# Altium schematic sheet AirQualityMonitor.SchDoc (continued)

## Library data of the parts
C1-C3 C7 C21 = 08056C106KAT2A: CAP CER 10UF 6.3V X7R 0805; Manufacturer: Kyocera AVX; Tolerance: 10%; Capacitance: 10uF; Case/Package: 0805; Case Code (Imperial): 0805; Case Code (Metric): 2012; Component Kind: Standard; ComponentLink1Description: http://www.farnell.com/datasheets/2095836.pdf; ComponentLink1URL: http://www.farnell.com/datasheets/2095836.pdf; ComponentLink2Description: http://avx.com/docs/Catalogs/cx7r.pdf; ComponentLink2URL: http://avx.com/docs/Catalogs/cx7r.pdf; ComponentLink3Description: http://www.mouser.com/ds/2/40/X7RDielectric-777024.pdf; ComponentLink3URL: http://www.mouser.com/ds/2/40/X7RDielectric-777024.pdf; ComponentLink4Description: https://www.verical.com/server-webapp/html/getDatasheet?partID=149687; ComponentLink4URL: https://www.verical.com/server-webapp/html/getDatasheet?partID=149687; ComponentLink5Description: http://www.avx.com/docs/catalogs/cx7r.pdf; ComponentLink5URL: http://www.avx.com/docs/catalogs/cx7r.pdf; ComponentLink6Description: http://catalogs.avx.com/SurfaceMount.pdf; ComponentLink6URL: http://catalogs.avx.com/SurfaceMount.pdf; ComponentLink7Description: http://datasheets.avx.com/X7RDielectric.pdf; ComponentLink7URL: http://datasheets.avx.com/X7RDielectric.pdf; Contact Plating: Matte,Tin; Depth: 1.25mm; Dielectric: X7R; Height: 1.52mm; Lead Free: Lead Free; Length: 2.01mm; Manufacturer Part Number: 08056C106KAT2A; Max Operating Temperature: 125°C; Min Operating Temperature: -55°C; Mount: PCB,Surface Mount; Number of Pins: 2; Package Quantity: 1; Packaging: Tape and Reel; Pricing 1: 1=0.83, 10=0.614, 100=0.4209, 500=0.33322, 1000=0.2806 (USD); Radiation Hardening: No; REACH SVHC: No SVHC; Stock 1: 0; Supplier 1: Digi-Key; Supplier Part Number 1: 478-5322-6-ND; Termination: SMD/SMT; Thickness: 0.055inch; Voltage: 6.3V; Voltage Rating: 6.3V; Voltage Rating (DC): 6.3V; Width: 0.049inch; Library Name: Breathalyzer.SchLib
C4 C10 C16 C18 = GRM188R71E104KA01D: CAP CER 0.1UF 25V X7R 0603; Manufacturer: Murata; Capacitance: 100nF; Material: Ceramic; Tolerance: 10%; Case/Package: 0603; Case Code (Imperial): 0603; Case Code (Metric): 1608; Component Kind: Standard; ComponentLink1Description: https://www.murata.com/~/media/webrenewal/support/library/catalog/products/capacitor/mlcc/c02e.ashx?la=en-us; ComponentLink1URL: https://www.murata.com/~/media/webrenewal/support/library/catalog/products/capacitor/mlcc/c02e.ashx?la=en-us; ComponentLink2Description: http://psearch.en.murata.com/capacitor/product/GRM188R71E103KA01%23.pdf; ComponentLink2URL: http://psearch.en.murata.com/capacitor/product/GRM188R71E103KA01%23.pdf; ComponentLink3Description: http://www.farnell.com/datasheets/1747454.pdf; ComponentLink3URL: http://www.farnell.com/datasheets/1747454.pdf; ComponentLink4Description: http://www.jameco.com/Jameco/Products/ProdDS/1857495.pdf; ComponentLink4URL: http://www.jameco.com/Jameco/Products/ProdDS/1857495.pdf; ComponentLink5Description: https://www.verical.com/server-webapp/html/getDatasheet?partID=44549; ComponentLink5URL: https://www.verical.com/server-webapp/html/getDatasheet?partID=44549; ComponentLink6Description: https://produktinfo.conrad.com/datenblaetter/450000-474999/451555-da-01-en-VS_KONDENSATOR_0603.pdf; ComponentLink6URL: https://produktinfo.conrad.com/datenblaetter/450000-474999/451555-da-01-en-VS_KONDENSATOR_0603.pdf; Depth: 0.8mm; Dielectric: X7R; Height: 0.8mm; Lead Free: Lead Free; Length: 1.6mm; Manufacturer Part Number: GRM188R71E104KA01D; Max Operating Temperature: 125°C; Min Operating Temperature: -55°C; Mount: Surface Mount; Number of Pins: 2; Package Quantity: 1; Packaging: Tape and Reel; Pricing 1: 1=0.13 (USD); Radiation Hardening: No; REACH SVHC: Unknown; Stock 1: 4000; Supplier 1: Digi-Key; Supplier Part Number 1: 490-1524-6-ND; Termination: SMD/SMT; Thickness: 0.035inch; Voltage Rating: 25V; Voltage Rating (DC): 25V; Weight: 7.1E-05oz; Width: 0.031inch; Library Name: Breathalyzer.SchLib
  C4 C10: Voltage: 25V
C5 C11 = GRM188R61A105KA61J: Multilayer Ceramic Capacitors MLCC - SMD/SMT 0603 1uF 10volts X5R 10%; Manufacturer: Murata; Voltage Rating: 10V; Case/Package: 0603; Case Code (Imperial): 0603; Case Code (Metric): 1608; Component Kind: Standard; ComponentLink1Description: https://www.murata.com/~/media/webrenewal/support/library/catalog/products/capacitor/mlcc/c02e.ashx?la=en-us; ComponentLink1URL: https://www.murata.com/~/media/webrenewal/support/library/catalog/products/capacitor/mlcc/c02e.ashx?la=en-us; Depth: 0.8mm; Dielectric: X5R; Height: 0.8mm; Length: 1.6mm; Manufacturer Part Number: GRM188R61A105KA61J; Max Operating Temperature: 85°C; Min Operating Temperature: -55°C; Mount: Surface Mount; Package Quantity: 1; Packaging: Tape and Reel; Radiation Hardening: No; REACH SVHC: No SVHC; Stock 1: 100000; Supplier 1: Digi-Key; Supplier Part Number 1: 490-6408-1-ND; Termination: SMD/SMT; Thickness: 0.035inch; Tolerance: 10%; Voltage Rating (DC): 10V; Width: 0.031inch; Library Name: Breathalyzer.SchLib
C6 = CC0805KKX7R8BB474: CAP CER 0.47UF 25V X7R 0805; Manufacturer: Yageo; Capacitance: 470nF; Dissipation Factor: 5%; Insulation Resistance: 10GR; Tolerance: 10%; Case/Package: 0805; Case Code (Imperial): 0805; Case Code (Metric): 2012; Component Kind: Standard; ComponentLink1Description: http://documents.rs-components.com/techlib://itc_doclist_GB.htm?stockno=6963572; ComponentLink1URL: http://documents.rs-components.com/techlib://itc_doclist_GB.htm?stockno=6963572; ComponentLink2Description: http://www.farnell.com/datasheets/1489800.pdf; ComponentLink2URL: http://www.farnell.com/datasheets/1489800.pdf; ComponentLink3Description: http://www.yageo.com/documents/recent/UPY-GPHC_X7R_6.3V-to-50V_18.pdf; ComponentLink3URL: http://www.yageo.com/documents/recent/UPY-GPHC_X7R_6.3V-to-50V_18.pdf; ComponentLink4Description: https://www.verical.com/server-webapp/html/getDatasheet?partID=315216; ComponentLink4URL: https://www.verical.com/server-webapp/html/getDatasheet?partID=315216; ComponentLink5Description: http://www.mouser.com/ds/2/447/Yageo_UPY-GP_NP0_16V-to-50V_9-358835.pdf; ComponentLink5URL: http://www.mouser.com/ds/2/447/Yageo_UPY-GP_NP0_16V-to-50V_9-358835.pdf; Composition: Ceramic; Depth: 1.25mm; Dielectric: X7R; Halogen Free: Halogen Free; Height: 1.25mm; Lead Free: Lead Free; Length: 2mm; Manufacturer Part Number: CC0805KKX7R8BB474; Max Operating Temperature: 125°C; Min Operating Temperature: -55°C; Mount: Surface Mount; Number of Pins: 2; Package Quantity: 3000; Packaging: Tape and Reel; Pricing 1: 1=0.29, 10=0.197, 100=0.0997, 500=0.06824, 1000=0.06037 (USD); Radiation Hardening: No; REACH SVHC: No SVHC; Stock 1: 0; Supplier 1: Digi-Key; Supplier Part Number 1: 311-1364-6-ND; Termination: SMD/SMT; Thickness: 0.049inch; Voltage: 25V; Voltage Rating: 25V; Voltage Rating (DC): 25V; Width: 0.049inch; Library Name: Breathalyzer.SchLib
C8 C9 C15 C17 = TMK316BJ106ML-T: CAP CER 10UF 25V X5R 1206; Manufacturer: Taiyo Yuden; Tolerance: 10%; Case/Package: 1206; Case Code (Imperial): 1206; Case Code (Metric): 3216; Component Kind: Standard; ComponentLink1Description: https://www.yuden.co.jp/productdata/catalog/mlcc01_e.pdf; ComponentLink1URL: https://www.yuden.co.jp/productdata/catalog/mlcc01_e.pdf; ComponentLink2Description: http://www.farnell.com/datasheets/1481447.pdf; ComponentLink2URL: http://www.farnell.com/datasheets/1481447.pdf; ComponentLink3Description: http://www.farnell.com/datasheets/746258.pdf; ComponentLink3URL: http://www.farnell.com/datasheets/746258.pdf; Composition: Ceramic; Contact Plating: Nickel; Depth: 1.6mm; Dielectric: X5R; Halogen Free: Halogen Free; Height: 1.6mm; Lead Free: Lead Free; Length: 3.2mm; Manufacturer Part Number: TMK316BJ106ML-T; Max Operating Temperature: 85°C; Min Operating Temperature: -55°C; Mount: Surface Mount; Number of Pins: 2; Package Quantity: 1; Packaging: Tape and Reel; Pricing 1: 1=0.34, 10=0.236, 100=0.1339, 500=0.09454, 1000=0.08271 (USD); Radiation Hardening: No; REACH SVHC: Unknown; Stock 1: 0; Supplier 1: Digi-Key; Supplier Part Number 1: 587-2259-6-ND; Termination: SMD/SMT; Thickness: 0.071inch; Voltage Rating: 25V; Voltage Rating (DC): 25V; Width: 1.6mm; Library Name: Breathalyzer.SchLib
  C8 C15 C17: Voltage: 25V
C12 = GRM31CR60J107ME39K: Multilayer Ceramic Capacitors MLCC - SMD/SMT 1206 100uF 6.3volt X5R +/-20%; Manufacturer: Murata; Tolerance: 20%; Case/Package: 1206; Case Code (Imperial): 1206; Case Code (Metric): 3216; Component Kind: Standard; ComponentLink1Description: http://www.mouser.com/ds/2/281/GRM31A7U2H471JW31-01-1064911.pdf; ComponentLink1URL: http://www.mouser.com/ds/2/281/GRM31A7U2H471JW31-01-1064911.pdf; ComponentLink2Description: https://www.murata.com/~/media/webrenewal/support/library/catalog/products/capacitor/mlcc/c02e.ashx?la=en-us; ComponentLink2URL: https://www.murata.com/~/media/webrenewal/support/library/catalog/products/capacitor/mlcc/c02e.ashx?la=en-us; Depth: 1.6mm; Dielectric: X5R; Height: 1.6mm; Lead Free: Lead Free; Length: 3.2mm; Manufacturer Part Number: GRM31CR60J107ME39K; Max Operating Temperature: 85°C; Min Operating Temperature: -55°C; Mount: Surface Mount; Package Quantity: 1; Packaging: Tape and Reel; Radiation Hardening: No; REACH SVHC: No SVHC; Stock 1: 0; Supplier 1: Digi-Key; Supplier Part Number 1: GRM31CR60J107ME39K-ND; Termination: SMD/SMT; Thickness: 0.071inch; Voltage: 6.3V; Voltage Rating: 6.3V; Voltage Rating (DC): 6.3V; Width: 0.063inch; Library Name: Breathalyzer.SchLib
C13 C14 C19 C20 = UMK107SD102KA-T: CAP CER 1000PF 50V 0603; Manufacturer: Taiyo Yuden; Dielectric Material: Ceramic; Tolerance: 10%; Case/Package: 0603; Case Code (Imperial): 0603; Case Code (Metric): 1608; Component Kind: Standard; ComponentLink1Description: http://www.yuden.co.jp/productdata/catalog/en/mlcc07_e.pdf; ComponentLink1URL: http://www.yuden.co.jp/productdata/catalog/en/mlcc07_e.pdf; ComponentLink2Description: http://documents.rs-components.com/techlib://itc_doclist_GB.htm?stockno=7587377; ComponentLink2URL: http://documents.rs-components.com/techlib://itc_doclist_GB.htm?stockno=7587377; ComponentLink3Description: http://ds.yuden.co.jp/TYCOMPAS/ut/detail.do?productNo=UMK107SD102KA-T&fileName=UMK107SD102KA-T_SS&mode=specSheetDownload; ComponentLink3URL: http://ds.yuden.co.jp/TYCOMPAS/ut/detail.do?productNo=UMK107SD102KA-T&fileName=UMK107SD102KA-T_SS&mode=specSheetDownload; Depth: 0.8mm; Halogen Free: Halogen Free; Height: 0.8mm; Lead Free: Lead Free; Length: 1.6mm; Manufacturer Part Number: UMK107SD102KA-T; Max Operating Temperature: 125°C; Min Operating Temperature: -55°C; Mount: Surface Mount; Number of Pins: 2; Package Quantity: 1; Packaging: Tape and Reel; Pricing 1: 1=0.36, 10=0.252, 100=0.1509, 500=0.1132, 1000=0.09559 (USD); Radiation Hardening: No; Stock 1: 4000; Supplier 1: Digi-Key; Supplier Part Number 1: 587-1070-6-ND; Termination: SMD/SMT; Thickness: 0.035inch; Voltage Rating: 50V; Voltage Rating (DC): 50V; Width: 0.8mm; Library Name: Breathalyzer.SchLib
  C14: Capacitance: 1nF; Voltage: 50V
D1 = LTST-C193TBKT-5A: Standard LEDs - SMD Blue 470nm 28mcd 5mA; Manufacturer: Vishay Lite-On; Dominant Wavelength: 475nm; Forward Current: 20mA; Forward Voltage: 2.8V; Lens Colour: Clear,Colorless; Lens Style: Clear,Colorless,Diffused,Rectangular; Lens Transparency: Clear; Luminous Intensity: 15mcd; Number of Elements: 1; Power Dissipation: 76mW; Reverse Voltage: 5V; Viewing Angle: 130°; Case/Package: 0603; Case Code (Imperial): 0603; Case Code (Metric): 1608; Colour: Blue; Component Kind: Standard; ComponentLink1Description: http://www.mouser.com/ds/2/239/Lite-On-LTST-C193TBKT-5A-187386.pdf; ComponentLink1URL: http://www.mouser.com/ds/2/239/Lite-On-LTST-C193TBKT-5A-187386.pdf; ComponentLink2Description: https://media.digikey.com/pdf/Data%20Sheets/Lite-On%20PDFs/LTST-C193TBKT-5A.pdf; ComponentLink2URL: https://media.digikey.com/pdf/Data%20Sheets/Lite-On%20PDFs/LTST-C193TBKT-5A.pdf; ComponentLink3Description: https://www.verical.com/server-webapp/html/getDatasheet?partID=293056; ComponentLink3URL: https://www.verical.com/server-webapp/html/getDatasheet?partID=293056; ComponentLink4Description: http://uk.rs-online.com/webdocs/0dc0/0900766b80dc0554.pdf; ComponentLink4URL: http://uk.rs-online.com/webdocs/0dc0/0900766b80dc0554.pdf; ComponentLink5Description: http://www1.futureelectronics.com/doc/LITE-ON/LTST-C193TBKT-5A.pdf; ComponentLink5URL: http://www1.futureelectronics.com/doc/LITE-ON/LTST-C193TBKT-5A.pdf; Depth: 0.8mm; Height: 0.35mm; Illumination Colour: Blue; Lead Free: Lead Free; Length: 1.6mm; Manufacturer Part Number: LTST-C193TBKT-5A; Max Operating Temperature: 80°C; Min Operating Temperature: -20°C; Mount: Surface Mount; Number of LEDs: 1; Number of Pins: 2; Package Quantity: 1; Packaging: Tape and Reel; Pricing 1: 1=0.43, 10=0.23, 50=0.23, 100=0.108, 1000=0.078, 10000=0.055 (USD); Radiation Hardening: No; Stock 1: 100531; Supplier 1: Mouser; Supplier Part Number 1: 859-LTSTC193TBKT5A; Test Current: 5mA; Width: 0.8mm; Library Name: Breathalyzer.SchLib
D2 = APT2012SRCPRV: LED 2X1.2MM 640NM RD WTR CLR SMD; Manufacturer: Kingbright; Forward Current: 20mA; Forward Voltage: 1.85V; Lens Color: Clear; Lens Style: Clear,Flat; Luminous Intensity: 100mcd; Number of LEDs: 1; Power Rating: 75mW; Viewing Angle: 120°; Wavelength: 655nm; Case/Package: 0805; Case Code (Imperial): 0805; Case Code (Metric): 2012; Color: Red; Component Kind: Standard; ComponentLink1Description: http://www.mouser.com/ds/2/216/APT2012SRCPRV-56885.pdf; ComponentLink1URL: http://www.mouser.com/ds/2/216/APT2012SRCPRV-56885.pdf; ComponentLink2Description: http://www.kingbrightusa.com/images/catalog/SPEC/APT2012SRCPRV.pdf; ComponentLink2URL: http://www.kingbrightusa.com/images/catalog/SPEC/APT2012SRCPRV.pdf; ComponentLink3Description: http://www.rapidonline.com/pdf/72-9588.pdf; ComponentLink3URL: http://www.rapidonline.com/pdf/72-9588.pdf; Dominant Wavelength: 640nm; Height: 0.75mm; Illumination Color: Red; Lead Free: Lead Free; Length: 2mm; Manufacturer Part Number: APT2012SRCPRV; Max Operating Temperature: 85°C; Min Operating Temperature: -40°C; Mount: Surface Mount; Number of Pins: 2; Package Quantity: 2000; Packaging: Tape and Reel; Pricing 1: 1=0.43, 10=0.266, 50=0.266, 100=0.128, 1000=0.082, 10000=0.053 (USD); Stock 1: 64032; Supplier 1: Mouser; Supplier Part Number 1: 604-APT2012SRCPRV; Test Current: 20mA; Width: 1.25mm; Library Name: Breathalyzer.SchLib
J1 = 10118194-0001LF: Conn Micro USB Type B RCP 5 POS 0.65mm Solder RA SMD 5 Terminal 1 Port T/R; MF: Amphenol FCI; Availability: Unavailable; Component Kind: Standard; MP: 10118194-0001LF; Package: None; Price: None; SnapEDA_Link: https://www.snapeda.com/parts/10118194-0001LF/Amphenol%20ICC/view-part/?ref=snap; Library Name: Breathalyzer.SchLib
J2 = I2C Testing: 1x4 Header for I2C Testing; Component Kind: Standard; Library Name: Breathalyzer.SchLib
J3 = S2B-PH-SM4-TB: Socket; wire-board; male; PIN: 2; 2mm; SMT; PH; 100V; 2A; -25Ã·85Â°C; MF: JST; Availability: Unavailable; Component Kind: Standard; MP: S2B-PH-SM4-TB; Package: None; Price: None; SnapEDA_Link: https://www.snapeda.com/parts/S2B-PH-SM4-TB/JST/view-part/?ref=snap; Library Name: Breathalyzer.SchLib
L1 L2 = VLF4012AT-2R2M1R5: FIXED IND 2.2UH 1.5A 87 MOHM SMD; Manufacturer: TDK; Core Material: Ferrite; DC Resistance (DCR): 87mR; Inductance Tolerance: 20%; Shielding: Shielded; Test Frequency: 100kHz; Case/Package: Nonstandard; Component Kind: Standard; ComponentLink1Description: http://www.farnell.com/datasheets/333336.pdf; ComponentLink1URL: http://www.farnell.com/datasheets/333336.pdf; ComponentLink2Description: http://www.onlinecomponents.com/productFiles/mf-TDK/e531_vlf4012a.pdf; ComponentLink2URL: http://www.onlinecomponents.com/productFiles/mf-TDK/e531_vlf4012a.pdf; Composition: Wirewound; DC Current: 1.5A; Depth: 3.8mm; Height: 1.2mm; Height - Seated (Max): 0.047inch; Inductance: 2.2uh; Lead Free: Lead Free; Length: 0.146inch; Manufacturer Part Number: VLF4012AT-2R2M1R5; Max DC Current: 1.5A; Max Operating Temperature: 105°C; Military Standard: Not; Min Operating Temperature: -40°C; Mount: Surface Mount; Number of Pins: 2; Package Quantity: 1000; Packaging: Tape and Reel; Pricing 1: 1=0.83, 10=0.725, 100=0.5175, 500=0.4347 (USD); Radiation Hardening: No; REACH SVHC: No SVHC; RMS Current (Irms): 1.5A; Saturation Current: 1.5A; Stock 1: 94427; Supplier 1: Digi-Key; Supplier Part Number 1: 445-3233-1-ND; Termination: SMD/SMT; Tolerance: 20%; Width: 0.138inch; Library Name: Breathalyzer.SchLib
Q1 Q2 = MMSS8050-L-TP: TRANS NPN 25V 1.5A SOT-23; Manufacturer: MCC; Collector Base Voltage (VCBO): 40V; Collector Emitter Saturation Voltage: 500mV; Element Configuration: Single; Emitter Base Voltage (VEBO): 5V; Gain Bandwidth Product: 100MHz; hFE Min: 40; Max Power Dissipation: 625mW; Case/Package: TO-236-3; Collector Emitter Breakdown Voltage: 25V; Collector Emitter Voltage (VCEO): 500mV; Component Kind: Standard; ComponentLink1Description: http://www.mccsemi.com/up_pdf/MMSS8050(SOT-23).pdf; ComponentLink1URL: http://www.mccsemi.com/up_pdf/MMSS8050(SOT-23).pdf; ComponentLink2Description: http://www.mouser.com/ds/2/258/MMSS8050(SOT-23)-276387.pdf; ComponentLink2URL: http://www.mouser.com/ds/2/258/MMSS8050(SOT-23)-276387.pdf; Lead Free: Lead Free; Manufacturer Part Number: MMSS8050-L-TP; Max Breakdown Voltage: 25V; Max Collector Current: 1.5A; Max Operating Temperature: 150°C; Min Operating Temperature: -55°C; Mount: Surface Mount; Package Quantity: 3000; Packaging: Tape and Reel; Polarity: NPN; Pricing 1: 1=0.21, 10=0.19, 25=0.1728, 100=0.1246, 250=0.07336, 500=0.0609, 1000=0.04152 (USD); Stock 1: 28424; Supplier 1: Digi-Key; Supplier Part Number 1: MMSS8050-L-TPMSDKR-ND; Transition Frequency: 100MHz; Library Name: Breathalyzer.SchLib
Q3 = DMP2045U-7: P-Channel MOSFET 045R at VGS=-4.5V ID = -4.3A; Manufacturer: Diodes; Component Kind: Standard; ComponentLink1Description: Datasheet; ComponentLink1URL: https://www.diodes.com/assets/Datasheets/DMP2045U.pdf; Manufacturer Part Number: DMP2045U-7; Pricing 1: 1=0.45, 10=0.289, 50=0.289, 100=0.124, 1000=0.095, 10000=0.064 (USD); Stock 1: 0; Supplier 1: Mouser; Supplier Part Number 1: 621-DMP2045U-7; Library Name: Breathalyzer.SchLib
R1 R3 R5 R6 R10 R11 R17 R18 = RC0805FR-0710KL: RES SMD 10K OHM 1% 1/8W 0805; Manufacturer: Yageo; Max Power Dissipation: 125mW; Resistance: 10kR; Temperature Coefficient: 100ppm/°C; Voltage Rating: 150V; Case/Package: 0805; Case Code (Imperial): 0805; Case Code (Metric): 2012; Component Kind: Standard; ComponentLink1Description: http://www.yageo.com/documents/recent/PYu-RC_Group_51_RoHS_L_9.pdf; ComponentLink1URL: http://www.yageo.com/documents/recent/PYu-RC_Group_51_RoHS_L_9.pdf; ComponentLink2Description: http://www.farnell.com/datasheets/444164.pdf; ComponentLink2URL: http://www.farnell.com/datasheets/444164.pdf; ComponentLink3Description: http://www.yageo.ru/pdf/RC0805.pdf; ComponentLink3URL: http://www.yageo.ru/pdf/RC0805.pdf; Composition: Thick Film; Depth: 1.25mm; Features: Moisture Resistant; Height: 0.024inch; Lead Free: Lead Free; Length: 2mm; Manufacturer Part Number: RC0805FR-0710KL; Max Operating Temperature: 155°C; Military Standard: Not; Min Operating Temperature: -55°C; Number of Terminations: 2; Package Quantity: 1; Packaging: Tape and Reel; Power Rating: 125mW; Pricing 1: 1=0.1, 10=0.046, 100=0.0186, 1000=0.00835, 2500=0.00725 (USD); REACH SVHC: Unknown; Stock 1: 50767607; Supplier 1: Digi-Key; Supplier Part Number 1: 311-10.0KCRDKR-ND; Termination: SMD/SMT; Width: 0.049inch; Library Name: Breathalyzer.SchLib
  R1 R17 R18: Tolerance: 1%
R2 R9 = RC0805JR-07100RL: RES, THICK FILM, 100R, 5%, 0.125W, 0805; Manufacturer: Yageo; Power Rating: 125mW; Temperature Coefficient: 100ppm/°C; Voltage Rating: 150V; Case/Package: 0805; Case Code (Imperial): 0805; Case Code (Metric): 2012; Component Kind: Standard; ComponentLink1Description: http://www.farnell.com/datasheets/444164.pdf; ComponentLink1URL: http://www.farnell.com/datasheets/444164.pdf; ComponentLink2Description: http://www.farnell.com/datasheets/2167052.pdf; ComponentLink2URL: http://www.farnell.com/datasheets/2167052.pdf; ComponentLink3Description: http://www.farnell.com/datasheets/442941.pdf; ComponentLink3URL: http://www.farnell.com/datasheets/442941.pdf; ComponentLink4Description: http://www.yageo.ru/pdf/RC0805.pdf; ComponentLink4URL: http://www.yageo.ru/pdf/RC0805.pdf; Composition: Thick Film; Contact Plating: Lead,Matte,Tin; Lead Free: Lead Free; Manufacturer Part Number: RC0805JR-07100RL; Max Operating Temperature: 155°C; Min Operating Temperature: -55°C; Packaging: Tape and Reel; Pricing 1: 1=0.11, 10=0.026, 50=0.026, 100=0.009, 1000=0.007, 10000=0.004 (USD); Radiation Hardening: No; REACH SVHC: Unknown; Resistance: 100R; Stock 1: 497207; Supplier 1: Mouser; Supplier Part Number 1: 603-RC0805JR-07100RL; Termination: SMD/SMT; Tolerance: 5%; Library Name: Breathalyzer.SchLib
R4 = RC0603FR-07100KL: YAGEO         RC0603FR-07100KL             RES, THICK FILM, 100K, 1%, 0.1W, 0603; Power Rating: 100mW; Resistance: 100kR; Temperature Coefficient: 100ppm/°C; Voltage Rating: 50V; Working Voltage: 75V; Case/Package: 0603; Case Code (Imperial): 0603; Case Code (Metric): 1608; Component Kind: Standard; ComponentLink1Description: http://www.farnell.com/datasheets/2167052.pdf; ComponentLink1URL: http://www.farnell.com/datasheets/2167052.pdf; ComponentLink2Description: http://www.farnell.com/datasheets/1868787.pdf; ComponentLink2URL: http://www.farnell.com/datasheets/1868787.pdf; ComponentLink3Description: http://www.farnell.com/datasheets/1738695.pdf; ComponentLink3URL: http://www.farnell.com/datasheets/1738695.pdf; ComponentLink4Description: http://documents.rs-components.com/techlib://itc_doclist_GB.htm?stockno=5048940; ComponentLink4URL: http://documents.rs-components.com/techlib://itc_doclist_GB.htm?stockno=5048940; ComponentLink5Description: http://www.farnell.com/datasheets/443971.pdf; ComponentLink5URL: http://www.farnell.com/datasheets/443971.pdf; Composition: Thick Film; Depth: 0.8mm; Height: 0.45mm; Lead Free: Lead Free; Length: 1.6mm; Manufacturer: Yageo; Manufacturer Part Number: RC0603FR-07100KL; Max Operating Temperature: 155°C; Military Standard: Not; Min Operating Temperature: -55°C; Number of Pins: 2; Number of Terminations: 2; Packaging: Tape and Reel; Pricing 1: 1=0.1, 10=0.018, 50=0.018, 100=0.006, 1000=0.005, 10000=0.003 (USD); REACH SVHC: No SVHC; Stock 1: 667937; Supplier 1: Mouser; Supplier Part Number 1: 603-RC0603FR-07100KL; Termination: SMD/SMT; Tolerance: 1%; Voltage Rating (DC): 50V; Width: 0.8mm; Library Name: Breathalyzer.SchLib
R7 = CRCW080518K0FKEA: RES SMD 18K OHM 1% 1/8W 0805; Manufacturer: Vishay; Max Power Dissipation: 125mW; Resistance: 18kR; Temperature Coefficient: 100ppm/°C; Voltage Rating: 150V; Case/Package: 0805; Case Code (Imperial): 0805; Case Code (Metric): 2012; Component Kind: Standard; ComponentLink1Description: http://www.vishay.com/docs/20035/dcrcwe3.pdf; ComponentLink1URL: http://www.vishay.com/docs/20035/dcrcwe3.pdf; ComponentLink2Description: https://www.distrelec.biz/Web/Downloads/a_/en/flD-CRCW-e3_data_en.pdf?mime=application%2Fpdf; ComponentLink2URL: https://www.distrelec.biz/Web/Downloads/a_/en/flD-CRCW-e3_data_en.pdf?mime=application%2Fpdf; ComponentLink3Description: http://www.farnell.com/datasheets/2046716.pdf; ComponentLink3URL: http://www.farnell.com/datasheets/2046716.pdf; ComponentLink4Description: http://www.alliedelec.com/m/d/0c934d6939ccefa68dbe0b96d821c8cf.pdf; ComponentLink4URL: http://www.alliedelec.com/m/d/0c934d6939ccefa68dbe0b96d821c8cf.pdf; ComponentLink5Description: http://uk.rs-online.com/webdocs/0d98/0900766b80d98b26.pdf; ComponentLink5URL: http://uk.rs-online.com/webdocs/0d98/0900766b80d98b26.pdf; ComponentLink6Description: http://www.mouser.com/ds/2/427/dcrcwe3-109170.pdf; ComponentLink6URL: http://www.mouser.com/ds/2/427/dcrcwe3-109170.pdf; Composition: Thick Film; Contact Plating: Tin; Depth: 1.25mm; Features: Automotive AEC-Q200; Height: 0.45mm; Lead Free: Lead Free; Length: 2mm; Manufacturer Part Number: CRCW080518K0FKEA; Max Operating Temperature: 155°C; Military Standard: Not; Min Operating Temperature: -55°C; Number of Pins: 2; Number of Terminations: 2; Package Quantity: 10; Packaging: Tape and Reel; Power Rating: 125mW; Pricing 1: 1=0.1, 10=0.069, 100=0.028, 1000=0.01256, 2500=0.0109 (USD); Radiation Hardening: No; REACH SVHC: Unknown; Stock 1: 0; Supplier 1: Digi-Key; Supplier Part Number 1: 541-18.0KCDKR-ND; Termination: SMD/SMT; Tolerance: 1%; Voltage Rating (DC): 150V; Weight: 0.000194oz; Width: 0.049inch; Working Voltage: 150V; Library Name: Breathalyzer.SchLib
R8 R20 R22 R23 = CRCW08051K60FKEA: RES SMD 1.6K OHM 1% 1/8W 0805; Manufacturer: Vishay; Max Power Dissipation: 125mW; Resistance: 1.6kR; Temperature Coefficient: 100ppm/°C; Voltage Rating: 150V; Case/Package: 0805; Case Code (Imperial): 0805; Case Code (Metric): 2012; Component Kind: Standard; ComponentLink1Description: http://www.vishay.com/docs/20035/dcrcwe3.pdf; ComponentLink1URL: http://www.vishay.com/docs/20035/dcrcwe3.pdf; ComponentLink2Description: http://uk.rs-online.com/webdocs/0d98/0900766b80d98b26.pdf; ComponentLink2URL: http://uk.rs-online.com/webdocs/0d98/0900766b80d98b26.pdf; ComponentLink3Description: http://www.mouser.com/ds/2/427/dcrcwe3-109170.pdf; ComponentLink3URL: http://www.mouser.com/ds/2/427/dcrcwe3-109170.pdf; ComponentLink4Description: http://www.farnell.com/datasheets/2111203.pdf; ComponentLink4URL: http://www.farnell.com/datasheets/2111203.pdf; ComponentLink5Description: http://www.farnell.com/datasheets/2046716.pdf; ComponentLink5URL: http://www.farnell.com/datasheets/2046716.pdf; ComponentLink6Description: http://www.farnell.com/datasheets/1847332.pdf; ComponentLink6URL: http://www.farnell.com/datasheets/1847332.pdf; ComponentLink7Description: http://www.farnell.com/datasheets/1697337.pdf; ComponentLink7URL: http://www.farnell.com/datasheets/1697337.pdf; ComponentLink8Description: https://www.distrelec.biz/Web/Downloads/a_/en/flD-CRCW-e3_data_en.pdf?mime=application%2Fpdf; ComponentLink8URL: https://www.distrelec.biz/Web/Downloads/a_/en/flD-CRCW-e3_data_en.pdf?mime=application%2Fpdf; Composition: Thick Film; Contact Plating: Tin; Depth: 1.25mm; Features: Automotive AEC-Q200; Height: 0.45mm; Lead Free: Lead Free; Length: 2mm; Manufacturer Part Number: CRCW08051K60FKEA; Max Operating Temperature: 155°C; Military Standard: Not; Min Operating Temperature: -55°C; Number of Pins: 2; Number of Terminations: 2; Package Quantity: 5000; Packaging: Tape and Reel; Power Rating: 125mW; Pricing 1: 1=0.1, 10=0.069, 100=0.028, 1000=0.01256, 2500=0.0109 (USD); Radiation Hardening: No; REACH SVHC: Unknown; Stock 1: 12623; Supplier 1: Digi-Key; Supplier Part Number 1: 541-1.60KCDKR-ND; Termination: SMD/SMT; Tolerance: 1%; Voltage Rating (DC): 150V; Weight: 0.000194oz; Width: 0.049inch; Library Name: Breathalyzer.SchLib
R12 R19 R21 = RC0805FR-07470RL: RES SMD 470 OHM 1% 1/8W 0805; Manufacturer: Yageo; Max Power Dissipation: 125mW; Temperature Coefficient: 100ppm/°C; Voltage Rating: 150V; Case/Package: 0805; Case Code (Imperial): 0805; Case Code (Metric): 2012; Component Kind: Standard; ComponentLink1Description: http://www.yageo.com/documents/recent/PYu-RC_Group_51_RoHS_L_9.pdf; ComponentLink1URL: http://www.yageo.com/documents/recent/PYu-RC_Group_51_RoHS_L_9.pdf; ComponentLink2Description: http://www.farnell.com/datasheets/444164.pdf; ComponentLink2URL: http://www.farnell.com/datasheets/444164.pdf; ComponentLink3Description: http://documents.rs-components.com/techlib://itc_doclist_GB.htm?stockno=6182546; ComponentLink3URL: http://documents.rs-components.com/techlib://itc_doclist_GB.htm?stockno=6182546; ComponentLink4Description: http://www.yageo.ru/pdf/RC0805.pdf; ComponentLink4URL: http://www.yageo.ru/pdf/RC0805.pdf; Composition: Thick Film; Depth: 1.25mm; Features: Moisture Resistant; Height: 0.5mm; Lead Free: Lead Free; Lead Pitch: 0.4mm; Length: 2mm; Manufacturer Part Number: RC0805FR-07470RL; Max Operating Temperature: 155°C; Military Standard: Not; Min Operating Temperature: -55°C; Number of Pins: 2; Number of Terminations: 2; Package Quantity: 1; Packaging: Tape and Reel; Power Rating: 125mW; Pricing 1: 1=0.1, 10=0.046, 100=0.0186, 1000=0.00835, 2500=0.00725 (USD); REACH SVHC: Unknown; Stock 1: 2436062; Supplier 1: Digi-Key; Supplier Part Number 1: 311-470CRDKR-ND; Termination: SMD/SMT; Voltage Rating (DC): 150V; Width: 1.25mm; Library Name: Breathalyzer.SchLib
R13 R14 = RC0603FR-070RL: Thick Film Resistors - SMD 0.0ohm 1%; Manufacturer: Yageo; Current Rating: 1A; Max Power Dissipation: 100mW; Resistance: 0mR; Temperature Coefficient: 200ppm/°C; Voltage Rating: 50V; Working Voltage: 75V; Case/Package: 0603; Case Code (Imperial): 0603; Case Code (Metric): 1608; Component Kind: Standard; ComponentLink1Description: http://www.farnell.com/datasheets/1868787.pdf; ComponentLink1URL: http://www.farnell.com/datasheets/1868787.pdf; ComponentLink2Description: http://www.farnell.com/datasheets/443971.pdf; ComponentLink2URL: http://www.farnell.com/datasheets/443971.pdf; ComponentLink3Description: http://www.yageo.com/documents/recent/PYu-RC_Group_51_RoHS_L_9.pdf; ComponentLink3URL: http://www.yageo.com/documents/recent/PYu-RC_Group_51_RoHS_L_9.pdf; ComponentLink4Description: http://documents.rs-components.com/techlib://itc_doclist_GB.htm?stockno=5048827; ComponentLink4URL: http://documents.rs-components.com/techlib://itc_doclist_GB.htm?stockno=5048827; Composition: Thick Film; Contact Plating: Matte,Tin; Depth: 0.8mm; Features: Moisture Resistant; Height: 0.45mm; Lead Free: Lead Free; Length: 1.6mm; Manufacturer Part Number: RC0603FR-070RL; Max Operating Temperature: 155°C; Military Standard: Not; Min Operating Temperature: -55°C; Number of Pins: 2; Number of Terminations: 2; Package Quantity: 5000; Packaging: Tape and Reel; Power Rating: 100mW; Radiation Hardening: No; REACH SVHC: No SVHC; Stock 1: 0; Supplier 1: Mouser; Supplier Part Number 1: 311-0.0HRCT-ND; Termination: SMD/SMT; Voltage Rating (DC): 50V; Width: 0.031inch; Library Name: Breathalyzer.SchLib
R15 R16 = RC0805JR-074K7L: YAGEO         RC0805JR-074K7L             RES, THICK FILM, 4K7, 5%, 0.125W, 0805; Power Rating: 125mW; Resistance: 4.7kR; Temperature Coefficient: 100ppm/°C; Voltage Rating: 150V; Case/Package: Molded; Case Code (Imperial): 0805; Case Code (Metric): 2012; Component Kind: Standard; ComponentLink1Description: http://www.farnell.com/datasheets/442941.pdf; ComponentLink1URL: http://www.farnell.com/datasheets/442941.pdf; ComponentLink2Description: http://www.yageo.ru/pdf/RC0805.pdf; ComponentLink2URL: http://www.yageo.ru/pdf/RC0805.pdf; ComponentLink3Description: http://www.mouser.com/ds/2/447/Yageo_08112016_RC0805-968729.pdf; ComponentLink3URL: http://www.mouser.com/ds/2/447/Yageo_08112016_RC0805-968729.pdf; Composition: Thick Film; Contact Plating: Lead,Matte,Tin; Depth: 1.25mm; Lead Free: Lead Free; Length: 2mm; Manufacturer: Yageo; Manufacturer Part Number: RC0805JR-074K7L; Max Operating Temperature: 155°C; Military Standard: Not; Min Operating Temperature: -55°C; Packaging: Tape and Reel; Pricing 1: 1=0.1, 10=0.036, 100=0.0146, 1000=0.00654, 2500=0.00568 (USD); Radiation Hardening: No; REACH SVHC: Unknown; Stock 1: 4671769; Supplier 1: Digi-Key; Supplier Part Number 1: 311-4.7KARDKR-ND; Termination: SMD/SMT; Tolerance: 5%; Library Name: Breathalyzer.SchLib
R24 = ERJ-8BWFR010V: Current Sense Resistor, 0.01 ohm, 1206 [3216 Metric], 1 W,  1%, ERJ8B Series; Manufacturer: Panasonic; Resistance: 10mR; Temperature Coefficient: 200ppm/°C; Case/Package: 1206; Case Code (Imperial): 1206; Case Code (Metric): 3216; Component Kind: Standard; ComponentLink1Description: http://uk.rs-online.com/webdocs/13b8/0900766b813b869e.pdf; ComponentLink1URL: http://uk.rs-online.com/webdocs/13b8/0900766b813b869e.pdf; ComponentLink2Description: http://industrial.panasonic.com/www-data/pdf/AOA0000/AOA0000CE3.pdf; ComponentLink2URL: http://industrial.panasonic.com/www-data/pdf/AOA0000/AOA0000CE3.pdf; ComponentLink3Description: https://www.distrelec.biz/Web/Downloads/_t/ds/ERJ_eng_tds.pdf?mime=application%2Fpdf; ComponentLink3URL: https://www.distrelec.biz/Web/Downloads/_t/ds/ERJ_eng_tds.pdf?mime=application%2Fpdf; ComponentLink4Description: https://industrial.panasonic.com/cdbs/www-data/pdf/RDN0000/AOA0000C313.pdf; ComponentLink4URL: https://industrial.panasonic.com/cdbs/www-data/pdf/RDN0000/AOA0000C313.pdf; ComponentLink5Description: http://www.farnell.com/datasheets/39793.pdf; ComponentLink5URL: http://www.farnell.com/datasheets/39793.pdf; Composition: Low-Ohm-Resistor,Thick Film; Contact Plating: Tin; Depth: 1.6mm; Features: Automotive AEC-Q200; Height: 0.65mm; Lead Free: Lead Free; Length: 3.2mm; Manufacturer Part Number: ERJ-8BWFR010V; Max Operating Temperature: 155°C; Max Power Dissipation: 1W; Military Standard: Not; Min Operating Temperature: -55°C; Mount: Surface Mount; Number of Terminations: 2; Package Quantity: 1; Packaging: Tape and Reel; Power Rating: 1W; Pricing 1: 1=0.74, 10=0.618, 100=0.4148, 1000=0.2427, 2500=0.23475 (USD); Radiation Hardening: No; REACH SVHC: No SVHC; Stock 1: 131309; Supplier 1: Digi-Key; Supplier Part Number 1: P.010AUDKR-ND; Termination: SMD/SMT; Tolerance: 1%; Width: 1.6mm; Library Name: Breathalyzer.SchLib
R25 = CRCW080582R0FKEA: RES SMD 82 OHM 1% 1/8W 0805; Manufacturer: Vishay; Max Power Dissipation: 125mW; Temperature Coefficient: 100ppm/°C; Voltage Rating: 150V; Case/Package: 0805; Case Code (Imperial): 0805; Case Code (Metric): 2012; Component Kind: Standard; ComponentLink1Description: http://www.vishay.com/docs/20035/dcrcwe3.pdf; ComponentLink1URL: http://www.vishay.com/docs/20035/dcrcwe3.pdf; ComponentLink2Description: https://www.alliedelec.com/m/d/0c934d6939ccefa68dbe0b96d821c8cf.pdf; ComponentLink2URL: https://www.alliedelec.com/m/d/0c934d6939ccefa68dbe0b96d821c8cf.pdf; ComponentLink3Description: http://www.farnell.com/datasheets/1697337.pdf; ComponentLink3URL: http://www.farnell.com/datasheets/1697337.pdf; ComponentLink4Description: http://www.farnell.com/datasheets/2111203.pdf; ComponentLink4URL: http://www.farnell.com/datasheets/2111203.pdf; ComponentLink5Description: http://www.farnell.com/datasheets/2046716.pdf; ComponentLink5URL: http://www.farnell.com/datasheets/2046716.pdf; ComponentLink6Description: https://www.distrelec.biz/Web/Downloads/a_/en/flD-CRCW-e3_data_en.pdf?mime=application%2Fpdf; ComponentLink6URL: https://www.distrelec.biz/Web/Downloads/a_/en/flD-CRCW-e3_data_en.pdf?mime=application%2Fpdf; ComponentLink7Description: http://www.mouser.com/ds/2/427/dcrcwe3-109170.pdf; ComponentLink7URL: http://www.mouser.com/ds/2/427/dcrcwe3-109170.pdf; ComponentLink8Description: http://uk.rs-online.com/webdocs/0d98/0900766b80d98b26.pdf; ComponentLink8URL: http://uk.rs-online.com/webdocs/0d98/0900766b80d98b26.pdf; Composition: Thick Film; Contact Plating: Tin; Depth: 1.25mm; Features: Automotive AEC-Q200; Height: 0.45mm; Lead Free: Lead Free; Length: 2mm; Manufacturer Part Number: CRCW080582R0FKEA; Max Operating Temperature: 155°C; Military Standard: Not; Min Operating Temperature: -55°C; Number of Pins: 2; Number of Terminations: 2; Package Quantity: 10; Packaging: Tape and Reel; Power Rating: 125mW; Pricing 1: 1=0.1, 10=0.069, 100=0.028, 1000=0.01256, 2500=0.0109 (USD); Radiation Hardening: No; REACH SVHC: Unknown; Stock 1: 0; Supplier 1: Digi-Key; Supplier Part Number 1: 541-82.0CDKR-ND; Termination: SMD/SMT; Voltage Rating (DC): 150V; Weight: 0.000194oz; Width: 0.049inch; Library Name: Breathalyzer.SchLib
SW1 = PCM12SMTR: C & K COMPONENTS         PCM12SMTR             Slide Switch, SPDT, On-On, SMD, PCM Series, 300 mA; Manufacturer: ITT C&K; Actuator Length: 1.5mm; Actuator Material: Nylon,Polyamide; Actuator Type: Standard; Contact Current Rating: 300mA; Contact Resistance: 70mR; Insulation Resistance: 100mR; Max Voltage Rating (DC): 6V; Mechanical Life: 10000Cycles; Component Kind: Standard; ComponentLink1Description: https://www.ckswitches.com/media/1424/pcm.pdf; ComponentLink1URL: https://www.ckswitches.com/media/1424/pcm.pdf; ComponentLink2Description: https://products.avnet.com/shop/en/asia/switches-and-relays/switches/slide-switch/www.ckswitches.com/media/1424/pcm.pdf; ComponentLink2URL: https://products.avnet.com/shop/en/asia/switches-and-relays/switches/slide-switch/www.ckswitches.com/media/1424/pcm.pdf; ComponentLink3Description: http://www1.futureelectronics.com/doc/C%20&%20K%20COMPONENTS/PCM12SMTR.pdf; ComponentLink3URL: http://www1.futureelectronics.com/doc/C%20&%20K%20COMPONENTS/PCM12SMTR.pdf; ComponentLink4Description: http://www.farnell.com/datasheets/1789487.pdf; ComponentLink4URL: http://www.farnell.com/datasheets/1789487.pdf; Contact Material: Copper,Copper Alloy,Silver; Contact Plating: Silver; Current Rating: 300mA; Depth: 5mm; Lead Free: Lead Free; Length: 7.7mm; Manufacturer Part Number: PCM12SMTR; Max Current Rating: 300mA; Max Operating Temperature: 85°C; Min Operating Temperature: -20°C; Mount: Surface Mount; Orientation: Right Angle; Package Quantity: 1; Packaging: Tape and Reel; Pricing 1: 1=0.87, 10=0.83, 50=0.762, 100=0.726, 1000=0.581, 10000=0.528 (USD); REACH SVHC: No SVHC; Stock 1: 22229; Supplier 1: Mouser; Supplier Part Number 1: 611-PCM12SMTR; Switch Function: On-On; Switch Travel: 1.5mm; Termination: Gull Wing; Throw Configuration: SPDT; Voltage Rating (AC): 6V; Voltage Rating (DC): 6V; Width: 6.7mm; Library Name: Breathalyzer.SchLib
SW2 = PTS645SM43SMTR: Switch Tact 6mm 100gf h=4.3mm; MF: C&K; Availability: Unavailable; Component Kind: Standard; MP: PTS645SM43SMTR; Package: None; Price: None; SnapEDA_Link: https://www.snapeda.com/parts/PTS645SM43SMTR/C%26K/view-part/?ref=snap; Library Name: Breathalyzer.SchLib
SW3 SW4 = EVPAWCD4A: Tactile Switch; Manufacturer: Panasonic; Component Kind: Standard; ComponentLink1Description: http://datasheet.octopart.com/EVPAWCD4A-Panasonic-datasheet-96186218.pdf; ComponentLink1URL: http://datasheet.octopart.com/EVPAWCD4A-Panasonic-datasheet-96186218.pdf; Manufacturer Part Number: EVPAWCD4A; Pricing 1: 1=0.3, 10=0.289, 25=0.2788, 50=0.2686, 100=0.2582, 250=0.23756, 500=0.20658, 1000=0.17559, 5000=0.14719 (USD); Stock 1: 19414; Supplier 1: Digikey; Supplier Part Number 1: P123439CT-ND; Library Name: Breathalyzer.SchLib
U1 = BQ24075RGTT: TEXAS INSTRUMENTS   BQ24075RGTT   IC, BATTERY CHARGER,LI-ION, 1.5A, QFN-16; Max Input Voltage: 26V; Max Output Current: 4.5A; Max Output Voltage: 5.6V; Max Supply Voltage: 6.4V; Min Input Voltage: 4.3V; Min Output Voltage: 5.4V; Min Supply Voltage: 4.35V; Number of Cells: 1; Output Voltage: 4.2V; Case/Package: QFN; Component Kind: Standard; ComponentLink1Description: https://www.verical.com/server-webapp/html/getDatasheet?partID=313264; ComponentLink1URL: https://www.verical.com/server-webapp/html/getDatasheet?partID=313264; ComponentLink2Description: http://www.ti.com/lit/gpn/bq24075; ComponentLink2URL: http://www.ti.com/lit/gpn/bq24075; ComponentLink3Description: http://www.farnell.com/datasheets/1885912.pdf; ComponentLink3URL: http://www.farnell.com/datasheets/1885912.pdf; ComponentLink4Description: http://www.farnell.com/datasheets/2001589.pdf; ComponentLink4URL: http://www.farnell.com/datasheets/2001589.pdf; ComponentLink5Description: http://www.ti.com/lit/ds/symlink/bq24079.pdf; ComponentLink5URL: http://www.ti.com/lit/ds/symlink/bq24079.pdf; ComponentLink6Description: http://www.ti.com/general/docs/lit/getliterature.tsp?genericPartNumber=BQ24079&&fileType=pdf; ComponentLink6URL: http://www.ti.com/general/docs/lit/getliterature.tsp?genericPartNumber=BQ24079&&fileType=pdf; ComponentLink7Description: http://datasheet.iiic.cc/texas_instruments/BQ24075RGTT.pdf; ComponentLink7URL: http://datasheet.iiic.cc/texas_instruments/BQ24075RGTT.pdf; Contact Plating: Gold; Height: 0.95mm; Lead Free: Lead Free; Length: 3.15mm; Manufacturer: Texas Instruments; Manufacturer Part Number: BQ24075RGTT; Max Charge Current: 1.5A; Max Operating Temperature: 85°C; Min Operating Temperature: -40°C; Number of Pins: 16; Output Current: 1.5A; Package Quantity: 1; Packaging: Tape and Reel; Pricing 1: 1=2.59, 10=2.2, 50=2.2, 100=1.76, 1000=1.28, 10000=1.15 (USD); Radiation Hardening: No; REACH SVHC: No SVHC; Stock 1: 2131; Supplier 1: Mouser; Supplier Part Number 1: 595-BQ24075RGTT; Termination: SMD/SMT; Weight: 0.000808oz; Width: 3.15mm; Library Name: Breathalyzer.SchLib
U2 = MiCS-5524: 10-500ppm Ethanol Sensor; Manufacturer: Amphenol SGX Sensortech; Ammonia range: 1-500ppm; Carbon monoxide range: 1-1000ppm; Current Rating: 32mA; Heating power: 76mW typ, 71mW min, 81mW max; Heating resistance at nominal power: 74R typ, 66R min, 82R max; Heating voltage: 2.4V; Max heater power dissipation: 88mW; Max sensitive layer power dissipation: 8mW; Methane range: >1000ppm; Relative humidity range: 5-95%RH; Sensing resistance in air (R_0): 100 to 1500R; Supply Voltage Max: 5.1V; Supply Voltage Min: 4.9V; Category: Air Quality Sensors; Component Kind: Standard; ComponentLink1Description: Hook-up Guide; ComponentLink1URL: https://learn.adafruit.com/adafruit-mics5524-gas-sensor-breakout/overview; ComponentLink2Description: Manufacturer; ComponentLink2URL: https://sgx.cdistore.com/Products/Detail/MICS5524-SGX-Sensortech/333420/; ComponentLink3Description: Datasheet; ComponentLink3URL: https://www.mouser.com/datasheet/2/18/1084_Datasheet-MiCS-5524-rev-8-1144838.pdf; Ethanol range: 10-500ppm; Hydrogen range: 1-1000ppm; Maximum Operating Temp: +85C; Minimum Operating Temp: -30C; Mounting Style: SMD; Series: MICS; Storage temperature range: -40 to 120C; Supplier 1: Mouser; Supplier Part Number 1: 523-MICS-5524; Library Name: Breathalyzer.SchLib
U3 = TPS63002DRCR: IC REG BUCK BOOST 5V 1.6A 10SON; Manufacturer: Texas Instruments; Efficiency: 96%; Max Input Voltage: 5.5V; Max Output Current: 1.2A; Min Input Voltage: 1.8V; Number of Outputs: 1; Output Type: Fixed; Quiescent Current: 30uA; Switching Frequency: 1.5MHz; Synchronous Rectifier: Yes; Topology: Buck-Boost; Component Kind: Standard; ComponentLink1Description: http://www.farnell.com/datasheets/1854797.pdf; ComponentLink1URL: http://www.farnell.com/datasheets/1854797.pdf; ComponentLink2Description: http://www.ti.com/lit/ds/symlink/tps63001.pdf; ComponentLink2URL: http://www.ti.com/lit/ds/symlink/tps63001.pdf; ComponentLink3Description: http://focus.ti.com/lit/ds/symlink/tps63001.pdf; ComponentLink3URL: http://focus.ti.com/lit/ds/symlink/tps63001.pdf; ComponentLink4Description: http://www.ti.com/general/docs/lit/getliterature.tsp?genericPartNumber=TPS63002&&fileType=pdf; ComponentLink4URL: http://www.ti.com/general/docs/lit/getliterature.tsp?genericPartNumber=TPS63002&&fileType=pdf; Contact Plating: Gold; Lead Free: Lead Free; Manufacturer Part Number: TPS63002DRCR; Max Operating Temperature: 85°C; Max Output Voltage: 5V; Min Operating Temperature: -40°C; Min Output Voltage: 5V; Nominal Output Voltage: 5V; Number of Pins: 10; Operating Supply Voltage: 5.5V; Output Current: 1.2A; Output Voltage: 5V; Package Quantity: 1; Packaging: Tape and Reel; Pricing 1: 1=2.2, 10=1.87, 50=1.87, 100=1.5, 1000=1.09, 10000=0.973 (USD); Radiation Hardening: No; REACH SVHC: No SVHC; Stock 1: 3675; Supplier 1: Mouser; Supplier Part Number 1: 595-TPS63002DRCR; Weight: 0.000921oz; Library Name: Breathalyzer.SchLib
U5 = TPS63001DRCT: Conv DC-DC Single Non-Inv/Inv/Step Up/Step Down 1.8V to 5.5V 10-Pin VSON EP T/R; Manufacturer: Texas Instruments; Efficiency: 96%; Frequency: 1.5MHz; Max Output Current: 1.2A; Max Output Voltage: 3.3V; Number of Outputs: 1; Output Configuration: Positive; Output Type: Fixed; Quiescent Current: 30uA; Synchronous Rectifier: Yes; Topology: Buck-Boost; Component Kind: Standard; ComponentLink1Description: http://www.ti.com/lit/ds/symlink/tps63001.pdf; ComponentLink1URL: http://www.ti.com/lit/ds/symlink/tps63001.pdf; ComponentLink2Description: http://www.farnell.com/datasheets/1764456.pdf; ComponentLink2URL: http://www.farnell.com/datasheets/1764456.pdf; ComponentLink3Description: http://www.ti.com/general/docs/lit/getliterature.tsp?genericPartNumber=TPS63002&&fileType=pdf; ComponentLink3URL: http://www.ti.com/general/docs/lit/getliterature.tsp?genericPartNumber=TPS63002&&fileType=pdf; ComponentLink4Description: https://www.verical.com/server-webapp/html/getDatasheet?partID=188363; ComponentLink4URL: https://www.verical.com/server-webapp/html/getDatasheet?partID=188363; Contact Plating: Gold; Height: 0.9mm; Lead Free: Lead Free; Length: 3mm; Manufacturer Part Number: TPS63001DRCT; Max Input Voltage: 5.5V; Max Operating Temperature: 85°C; Max Supply Voltage: 5.5V; Min Input Voltage: 1.8V; Min Operating Temperature: -40°C; Min Output Voltage: 3.3V; Min Supply Voltage: 1.8V; Nominal Output Voltage: 3.3V; Number of Pins: 10; Output Current: 1.2A; Output Voltage: 3.3V; Package Quantity: 250; Packaging: Tape and Reel; Pricing 1: 1=2.53, 10=2.15, 50=2.15, 100=1.73, 1000=1.25, 10000=1.12 (USD); Radiation Hardening: No; REACH SVHC: No SVHC; Stock 1: 4204; Supplier 1: Mouser; Supplier Part Number 1: 595-TPS63001DRCT; Switching Frequency: 1.5MHz; Termination: SMD/SMT; Weight: 0.000921oz; Width: 3mm; Library Name: Breathalyzer.SchLib
U6 = BQ27441DRZR-G1A: IC BATT FUEL GAUGE LI-ION 12SON; Manufacturer: Texas Instruments; Capacity: 8000mAh; Interface: I2C; Number of Cells: 1; Operating Supply Voltage: 4.5V; Output Current: 500uA; Output Voltage: 600mV; Case/Package: QFN; Component Kind: Standard; ComponentLink1Description: Datasheet; ComponentLink1URL: http://www.ti.com/lit/ds/symlink/bq27441-g1.pdf; Contact Plating: Gold; Lead Free: Lead Free; Manufacturer Part Number: BQ27441DRZR-G1A; Max Operating Temperature: 85°C; Min Operating Temperature: -40°C; Mount: Surface Mount; Number of Pins: 12; Package Quantity: 3000; Packaging: Tape and Reel; Pricing 1: 1=2.54, 10=2.16, 50=2.16, 100=1.73, 1000=1.25, 10000=1.13 (USD); Stock 1: 12994; Supplier 1: Mouser; Supplier Part Number 1: 595-BQ27441DRZR-G1A; Library Name: Breathalyzer.SchLib
U7 = CP2102N-A01-GQFN28: SILICON LABS         CP2102N-A01-GQFN28             Interface Bridges, USB to UART, 3 V, 3.6 V, QFN, 28 Pins, -40 C                          New; Max Supply Voltage: 3.6V; Min Supply Voltage: 3V; Case/Package: QFN; Component Kind: Standard; Manufacturer: Silicon Labs; Manufacturer Part Number: CP2102N-A01-GQFN28; Max Operating Temperature: 85°C; Min Operating Temperature: -40°C; Number of Pins: 28; Pricing 1: 1=1.4, 25=1.3516, 100=1.2995, 1000=1.22501 (USD); REACH SVHC: Unknown; Stock 1: 15372; Supplier 1: Digi-Key; Supplier Part Number 1: 336-3694-ND; Library Name: Breathalyzer.SchLib
U8 = ESP32-WROOM-32D: ESP32 Module; Manufacturer: Espressif Systems; Component Kind: Standard; ComponentLink1Description: Datasheet; ComponentLink1URL: https://www.mouser.com/datasheet/2/891/esp32-wroom-32d_esp32-wroom-32u_datasheet_en-1365844.pdf; Manufacturer Part Number: ESP32-WROOM-32D; Pricing 1: 1=3.8, 10=3.8, 50=3.8, 100=3.8, 1000=3.8, 10000=3.8 (USD); Stock 1: 1150; Supplier 1: Mouser; Supplier Part Number 1: 356-ESP32WROOM-32D; Library Name: Breathalyzer.SchLib
